# Altium schematic sheet Amplis.SchDoc(Amplis)
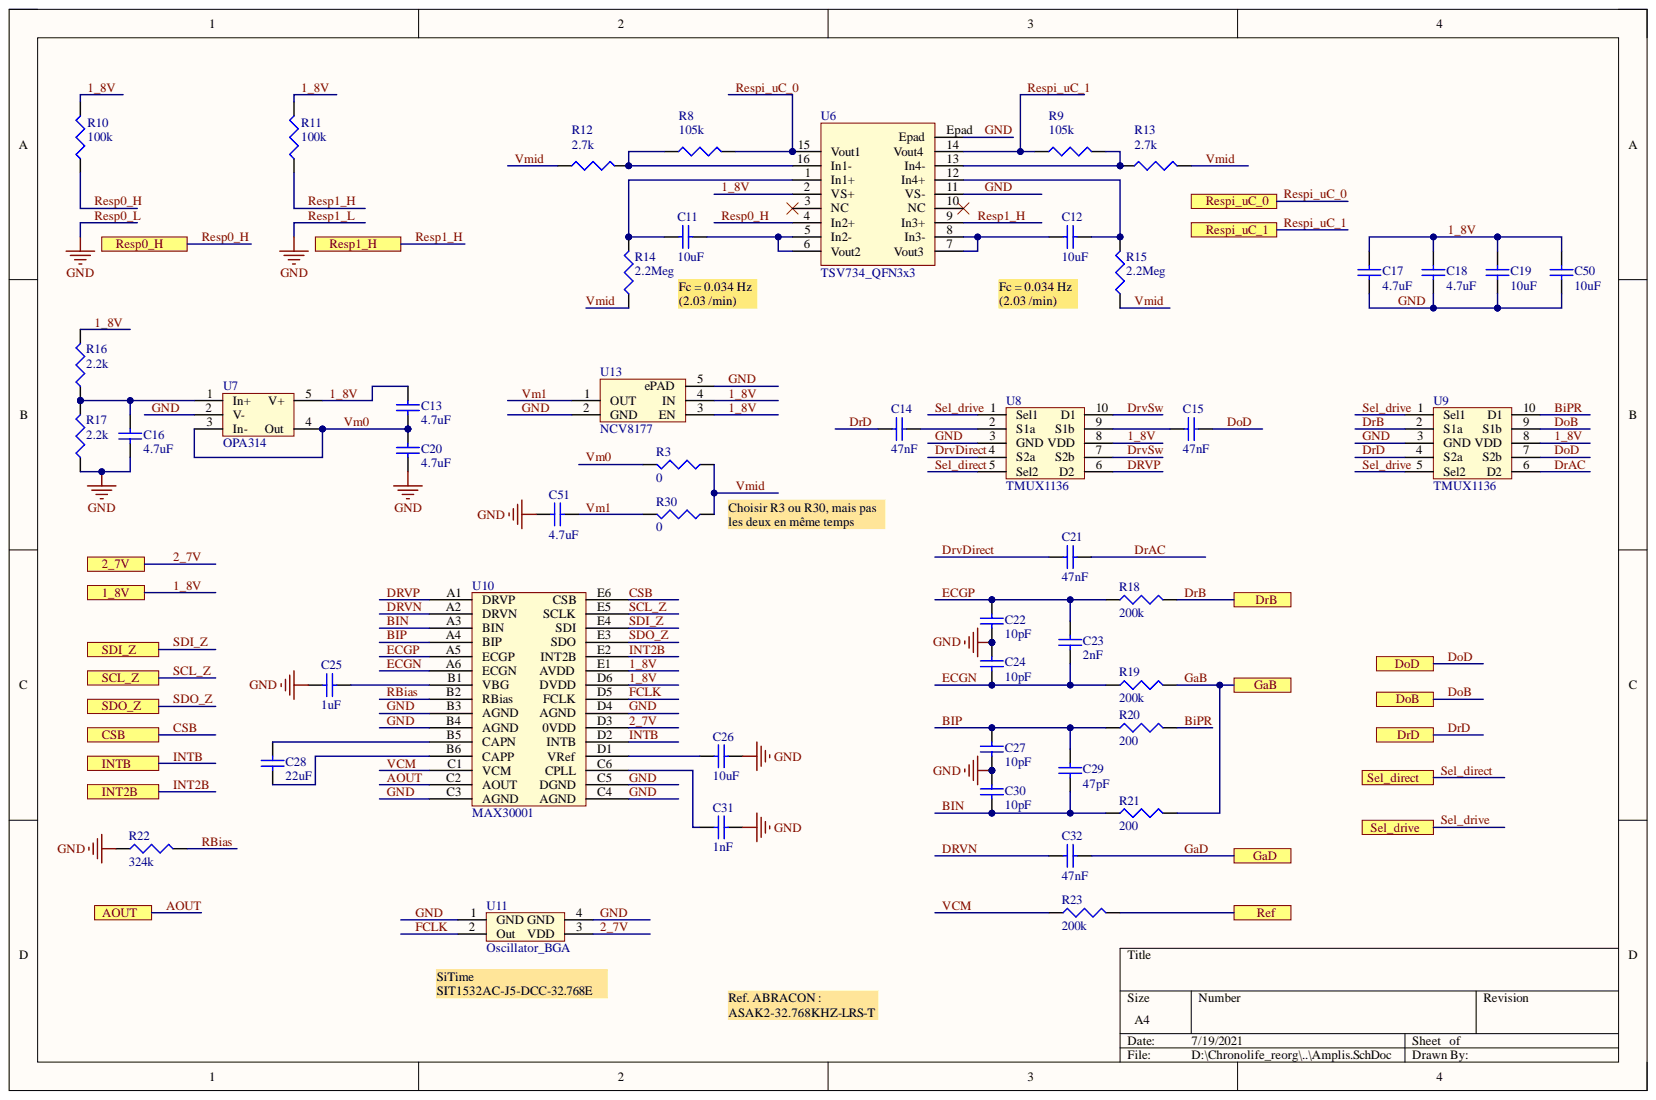
[diagram p0: Amplis.SchDoc]

## Parts
C11  10uF  [0402_GC]
C12  10uF  [0402_GC]
C13  4.7uF  [0402_GC]
C14  47nF  [0402_GC]
C15  47nF  [0402_GC]
C16  4.7uF  [0402_GC]
C17  4.7uF  [0402_GC]
C18  4.7uF  [0402_GC]
C19  10uF  [0402_GC]
C20  4.7uF  [0402_GC]
C21  47nF  [0402_GC]
C22  10pF  [0402_GC]
C23  2nF  [0402_GC]
C24  10pF  [0402_GC]
C25  1uF  [0402_GC]
C26  10uF  [0402_GC]
C27  10pF  [0402_GC]
C28  22uF  [0603C_GC]
C29  47pF  [0402_GC]
C30  10pF  [0402_GC]
C31  1nF  [0402_GC]
C32  47nF  [0402_GC]
C50  10uF  [0402_GC]
C51  4.7uF  [0402_GC]
R3  0  [0402_GC]
R8  105k  [0402_GC]
R9  105k  [0402_GC]
R10  100k  [0402_GC]
R11  100k  [0402_GC]
R12  2.7k  [0402_GC]
R13  2.7k  [0402_GC]
R14  2.2Meg  [0402_GC]
R15  2.2Meg  [0402_GC]
R16  2.2k  [0402_GC]
R17  2.2k  [0402_GC]
R18  200k  [0402_GC]
R19  200k  [0402_GC]
R20  200  [0402_GC]
R21  200  [0402_GC]
R22  324k  [0402_GC]
R23  200k  [0402_GC]
R30  0  [0402_GC]
U6  TSV734_QFN3x3  [QFN16_PAD_GC_BRICOLAGE]
U7  OPA314  [SC70]
U8  TMUX1136  [USON10_GC]
U9  TMUX1136  [USON10_GC]
U10  MAX30001  [WLP30_GC]
U11  Oscillator_BGA  [Oscillator_BGA]
U13  NCV8177  [XDFN4]

## Ports
1_8V, 2_7V, AOUT, CSB, DoB, DoD, DrB, DrD, GaB, GaD, INT2B, INTB, Ref, Resp0_H, Resp1_H, Respi_uC_0, Respi_uC_1, SCL_Z, SDI_Z, SDO_Z, Sel_direct, Sel_drive

## Nets
1_8V: C13.2 C17.2 C18.2 C19.2 C50.2 R10.2 R11.2 R16.2 U6.2(VS+) U7.5(V+) U8.8(VDD) U9.8(VDD) U10.D6(DVDD) U10.E1(AVDD) U13.3(EN) U13.4(IN) ; port 1_8V
2_7V: U10.D3(0VDD) U11.3(VDD) ; port 2_7V
AOUT: U10.C2(AOUT) ; port AOUT
BIN: C29.1 C30.1 R21.2 U10.A3(BIN)
BIP: C27.2 C29.2 R20.2 U10.A4(BIP)
BiPR: R20.1 U9.10(D1)
CSB: U10.E6(CSB) ; port CSB
DoB: U9.9(S1b) ; port DoB
DoD: C15.2 U9.7(S2b) ; port DoD
DrAC: C21.2 U9.6(D2)
DrB: R18.1 U9.2(S1a) ; port DrB
DrD: C14.1 U9.4(S2a) ; port DrD
DrvDirect: C21.1 U8.4(S2a)
DRVN: C32.1 U10.A2(DRVN)
DRVP: U8.6(D2) U10.A1(DRVP)
DrvSw: U8.7(S2b) U8.10(D1)
ECGN: C23.1 C24.1 R19.2 U10.A6(ECGN)
ECGP: C22.2 C23.2 R18.2 U10.A5(ECGP)
FCLK: U10.D5(FCLK) U11.2(Out)
GaB: R19.1 R21.1 ; port GaB
GaD: C32.2 ; port GaD
GND: C16.1 C17.1 C18.1 C19.1 C20.1 C22.1 C24.2 C25.1 C26.2 C27.1 C30.2 C31.2 C50.1 C51.1 R17.1 R22.1 U6.11(VS-) U6.Epad U7.2(V-) U8.3(GND) U9.3(GND) U10.B3(AGND) U10.B4(AGND) U10.C3(AGND) U10.C4(AGND) U10.C5(DGND) U10.D4(AGND) U11.1(GND) U11.4(GND) U13.2(GND) U13.5(ePAD)
INT2B: U10.E2(INT2B) ; port INT2B
INTB: U10.D2(INTB) ; port INTB
RBias: R22.2 U10.B2(RBias)
Ref: R23.1 ; port Ref
Resp0_H: R10.1 U6.4(In2+) ; port Resp0_H
Resp1_H: R11.1 U6.9(In3+) ; port Resp1_H
Respi_uC_0: R8.2 U6.15(Vout1) ; port Respi_uC_0
Respi_uC_1: R9.1 U6.14(Vout4) ; port Respi_uC_1
SCL_Z: U10.E5(SCLK) ; port SCL_Z
SDI_Z: U10.E4(SDI) ; port SDI_Z
SDO_Z: U10.E3(SDO) ; port SDO_Z
Sel_direct: U8.5(Sel2) ; port Sel_direct
Sel_drive: U8.1(Sel1) U9.1(Sel1) U9.5(Sel2) ; port Sel_drive
VCM: R23.2 U10.C1(VCM)
Vm0: C13.1 C20.2 R3.2 U7.3(In-) U7.4(Out)
Vm1: C51.2 R30.2 U13.1(OUT)
Vmid: R3.1 R12.1 R13.2 R14.1 R15.1 R30.1
NetC11_1: C11.1 U6.5(In2-) U6.6(Vout2)
NetC11_2: C11.2 R14.2 U6.1(In1+)
NetC12_1: C12.1 R15.2 U6.12(In4+)
NetC12_2: C12.2 U6.7(Vout3) U6.8(In3-)
NetC14_2: C14.2 U8.2(S1a)
NetC15_1: C15.1 U8.9(S1b)
NetC16_2: C16.2 R16.1 R17.2 U7.1(In+)
NetC25_2: C25.2 U10.B1(VBG)
NetC26_1: C26.1 U10.D1(VRef)
NetC28_1: C28.1 U10.B6(CAPP)
NetC28_2: C28.2 U10.B5(CAPN)
NetC31_1: C31.1 U10.C6(CPLL)
NetR8_1: R8.1 R12.2 U6.16(In1-)
NetR9_2: R9.2 R13.1 U6.13(In4-)

Not connected: U6 3(NC) 10(NC)

## Library data of the parts
C11-C32 C50 C51 = Cap: Capacitor; Component Kind: Standard; LatestRevisionDate: 17-Jul-2002; LatestRevisionNote: Re-released for DXP Platform.; Library Name: Miscellaneous Devices.IntLib; PackageDescription: Radial Cap, Thru-Hole; 2 Leads; 0.3 in Pin Spacing; PackageReference: RAD-0.3; Published: 8-Jun-2000; Signal Integrity: Cap; Simulation: CAP; Publisher: Altium Limited
  C11 C12 C19 C26 C50: Value: 10uF
  C13 C16-C18 C20 C51: Value: 4.7uF
  C14 C15 C21 C32: Value: 47nF
  C22 C24 C27 C30: Value: 10pF
  C23: Value: 2nF
  C25: Value: 1uF
  C28: Value: 22uF
  C29: Value: 47pF
  C31: Value: 1nF
R3 R8-R23 R30 = Res3: Resistor; Component Kind: Standard; LatestRevisionDate: 29-May-2009; LatestRevisionNote: IPC-7351 Footprint Added.; Library Name: Miscellaneous Devices.IntLib; PackageDescription: Chip Resistor; PackageReference: J1-0603; Published: 8-Jun-2000; Signal Integrity: Res; Simulation: RESISTOR; Publisher: Altium Limited
  R3 R30: Value: 0
  R8 R9: Value: 105k
  R10 R11: Value: 100k
  R12 R13: Value: 2.7k
  R14 R15: Value: 2.2Meg
  R16 R17: Value: 2.2k
  R18 R19 R23: Value: 200k
  R20 R21: Value: 200
  R22: Value: 324k
U6 = TSV734_QFN3x3: Component Kind: Standard; Library Name: Components_0.SchLib
U7 = OPA314: Component Kind: Standard; Library Name: Components_0.SchLib
U8 U9 = TMUX1136: Component Kind: Standard; Library Name: Components_0.SchLib
U10 = MAX30001: Component Kind: Standard; Library Name: Components_0.SchLib
U11 = Oscillator_BGA: Component Kind: Standard; Library Name: Components_0.SchLib
U13 = NCV8177: Component Kind: Standard; Library Name: Components_0.SchLib
